# Altium schematic sheet Input.SchDoc(Input)
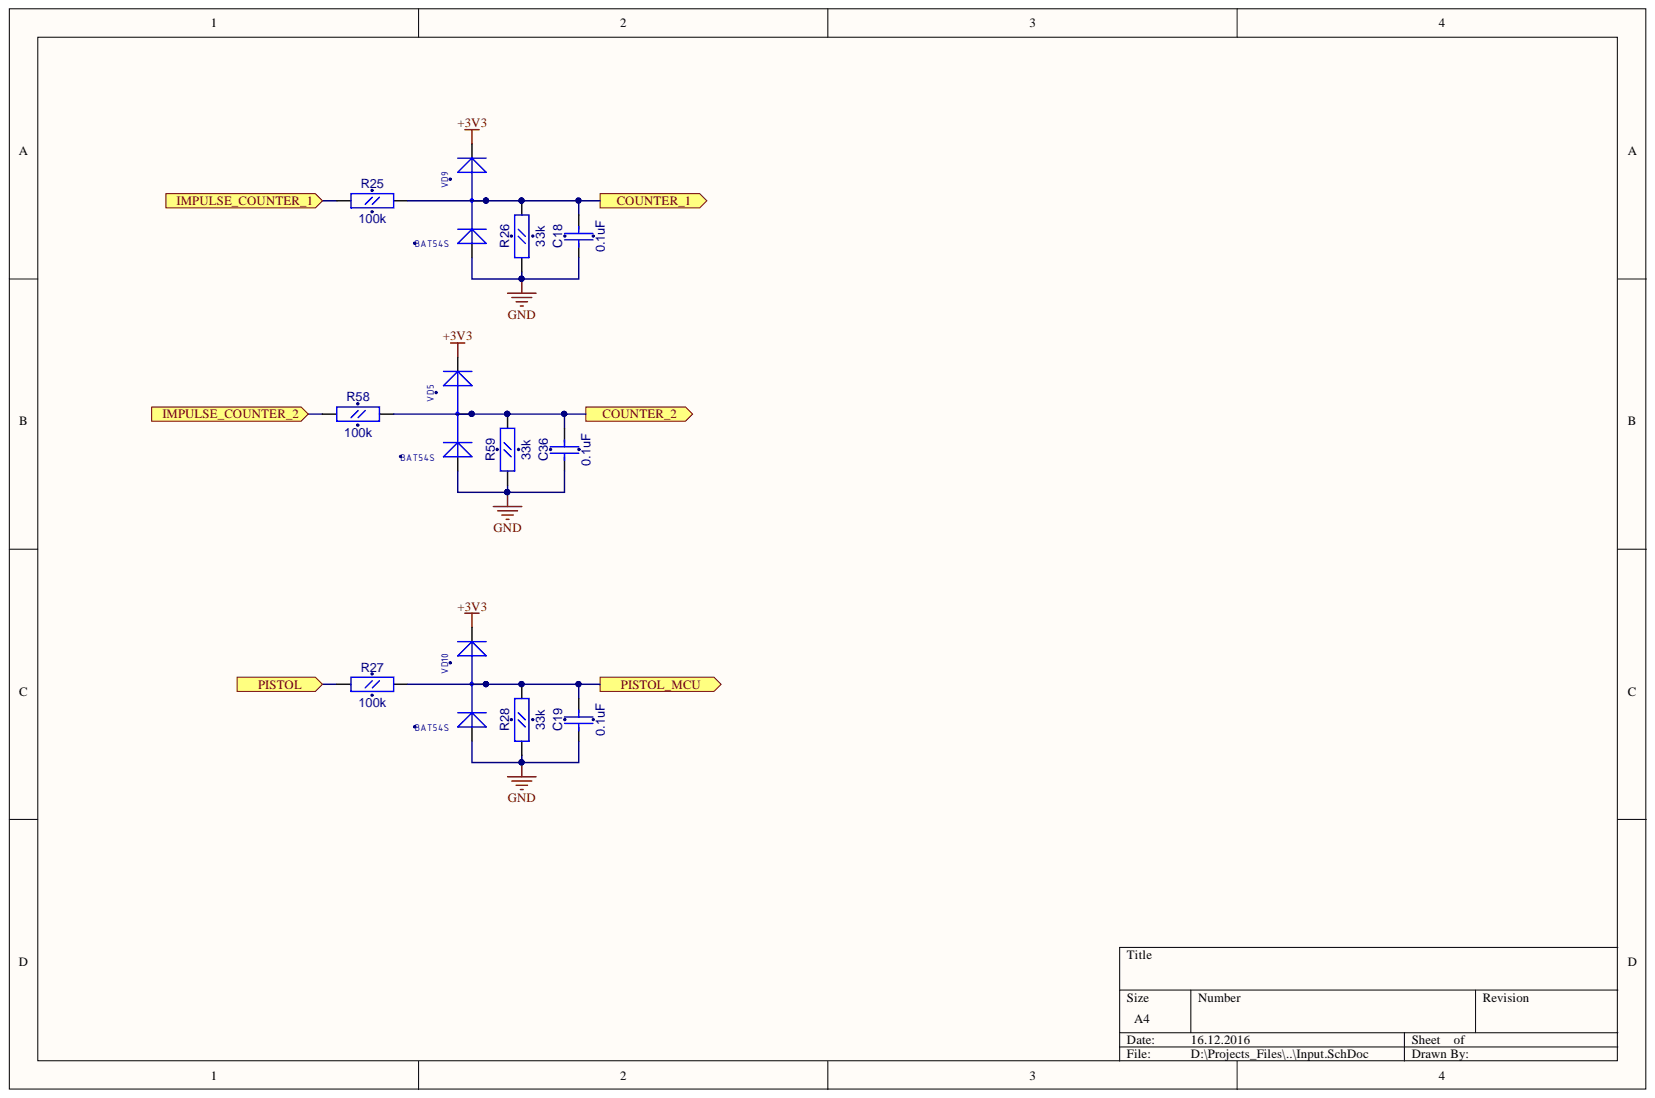
[diagram p0: Input.SchDoc]

## Parts
C18  0.1uF  [CC0603]
C19  0.1uF  [CC0603]
C36  0.1uF  [CC0603]
R25  100k  [RC0603]
R26  33k  [RC0603]
R27  100k  [RC0603]
R28  33k  [RC0603]
R58  100k  [RC0603]
R59  33k  [RC0603]
VD5  BAT54S  [SOT-23(GSD)]
VD9  BAT54S  [SOT-23(GSD)]
VD10  BAT54S  [SOT-23(GSD)]

## Ports
COUNTER_1, COUNTER_2, IMPULSE_COUNTER_1, IMPULSE_COUNTER_2, PISTOL, PISTOL_MCU

## Nets
+3V3: VD5.2 VD9.2 VD10.2
GND: C18.1 C19.1 C36.1 R26.1 R28.1 R59.1 VD5.1 VD9.1 VD10.1
COUNTER_1: C18.2 R25.2 R26.2 VD9.3 ; port COUNTER_1
COUNTER_2: C36.2 R58.2 R59.2 VD5.3 ; port COUNTER_2
IMPULSE_COUNTER_1: R25.1 ; port IMPULSE_COUNTER_1
IMPULSE_COUNTER_2: R58.1 ; port IMPULSE_COUNTER_2
PISTOL: R27.1 ; port PISTOL
PISTOL_MCU: C19.2 R27.2 R28.2 VD10.3 ; port PISTOL_MCU

## Library data of the parts
C18 C19 C36 = CC0603: Component Kind: Standard; Description: CC0603; Library Name: gprs_modem_2.SchLib; Value: 0.1uF
R25-R28 R58 R59 = RC0603: Component Kind: Standard; Description: RC0603; Library Name: gprs_modem_2.SchLib
  R25 R27 R58: Value: 100k
  R26 R28 R59: Value: 33k
VD5 VD9 VD10 = BAT54S: 1.0 Ampere General Purpose Rectifier; ComponentField6: Published 8-Jun-2000, Modified 11-July-2000: SIM Model Added.; Component Kind: Standard; Library Name: gprs_modem_2.SchLib; Simulation: DIODE
